# Altium schematic sheet pultboard_power.SchDoc(pultboard_power)
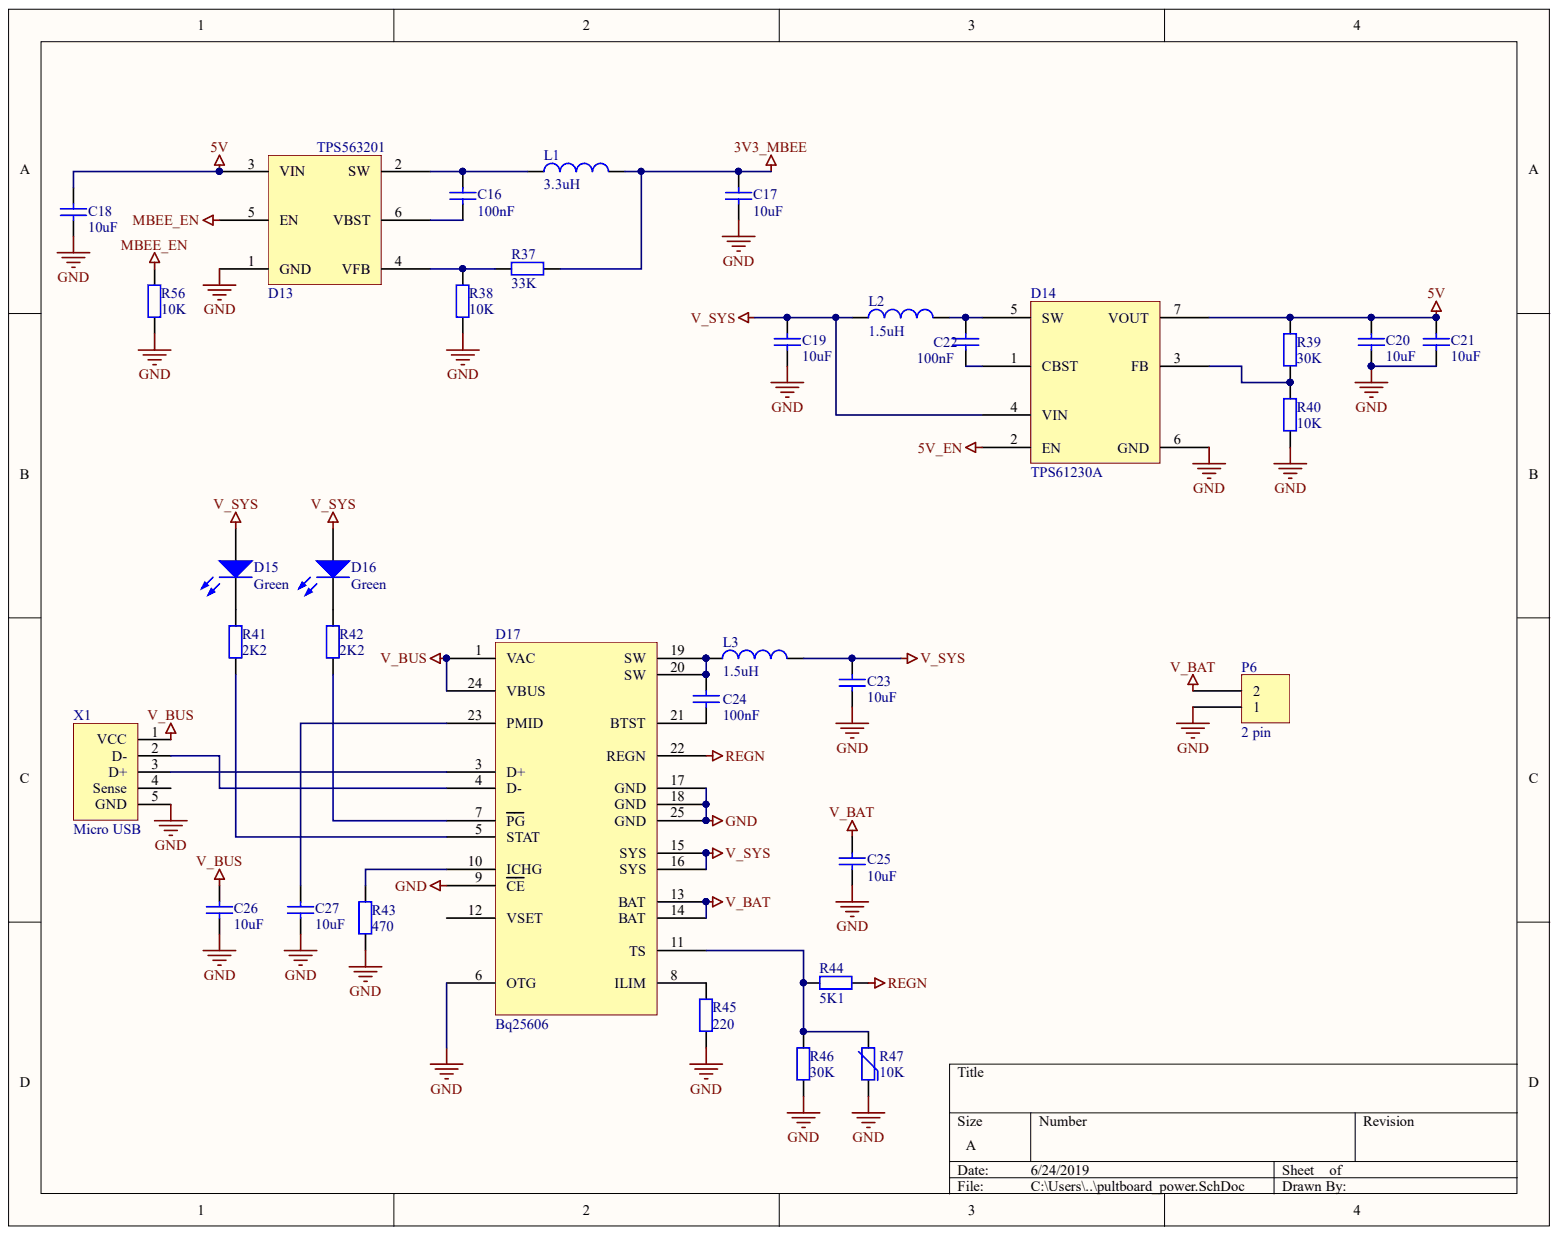
[diagram p0: pultboard_power.SchDoc]

## Parts
C16  value 100nF  [0402]  (hidden comment: Cap)
C17  value 10uF  [1206]  (hidden comment: Cap)
C18  value 10uF  [1206]  (hidden comment: Cap)
C19  value 10uF  [1206]  (hidden comment: Cap)
C20  value 10uF  [1206]  (hidden comment: Cap)
C21  value 10uF  [1206]  (hidden comment: Cap)
C22  value 100nF  [0402]  (hidden comment: Cap)
C23  value 10uF  [1206]  (hidden comment: Cap)
C24  value 100nF  [0402]  (hidden comment: Cap)
C25  value 10uF  [1206]  (hidden comment: Cap)
C26  value 10uF  [1206]  (hidden comment: Cap)
C27  value 10uF  [1206]  (hidden comment: Cap)
D13  TPS563201  [SOT23-6]
D14  TPS61230A  [VQFN7]
D15  Green  [D0603]
D16  Green  [D0603]
D17  Bq25606  [VQFN24]
L1  value 3.3uH  [SRN3015]  (hidden comment: Inductor)
L2  value 1.5uH  [SRN8040]  (hidden comment: Inductor)
L3  value 1.5uH  [SRN8040]  (hidden comment: Inductor)
P6  2 pin  [2 pin 5.08 terminal]
R37  value 33K  [0603]  (hidden comment: Res)
R38  value 10K  [0603]  (hidden comment: Res)
R39  value 30K  [0603]  (hidden comment: Res)
R40  value 10K  [0603]  (hidden comment: Res)
R41  value 2K2  [0603]  (hidden comment: Res)
R42  value 2K2  [0603]  (hidden comment: Res)
R43  value 470  [0603]  (hidden comment: Res)
R44  value 5K1  [0603]  (hidden comment: Res)
R45  value 220  [0603]  (hidden comment: Res)
R46  value 30K  [0603]  (hidden comment: Res)
R47  Resistance: 10K  [0603]  (hidden comment: Thermistor)
R56  value 10K  [0603]  (hidden comment: Res)
X1  Micro USB  Database Table Name: USB  [10104111]

## Nets
3V3_MBEE: C17.2 L1.2 R37.2
5V: C18.2 C20.2 C21.2 D13.3(VIN) D14.7(VOUT) R39.2
5V_EN: D14.2(EN)
GND: C17.1 C18.1 C19.1 C20.1 C21.1 C23.1 C25.1 C26.1 C27.1 D13.1(GND) D14.6(GND) D17.6(OTG) D17.9(CE) D17.17(GND) D17.18(GND) D17.25(GND) P6.1 R38.1 R40.1 R43.1 R45.1 R46.1 R47.1 R56.1 X1.5(GND)
MBEE_EN: D13.5(EN) R56.2
REGN: D17.22(REGN) R44.2
V_BAT: C25.2 D17.13(BAT) D17.14(BAT) P6.2
V_BUS: C26.2 D17.1(VAC) D17.24(VBUS) X1.1(VCC)
V_SYS: C19.2 C23.2 D14.4(VIN) D15.1 D16.1 D17.15(SYS) D17.16(SYS) L2.1 L3.2
NetC16_1: C16.1 D13.6(VBST)
NetC16_2: C16.2 D13.2(SW) L1.1
NetC22_1: C22.1 D14.1(CBST)
NetC22_2: C22.2 D14.5(SW) L2.2
NetC24_1: C24.1 D17.21(BTST)
NetC24_2: C24.2 D17.19(SW) D17.20(SW) L3.1
NetC27_2: C27.2 D17.23(PMID)
NetD13_4: D13.4(VFB) R37.1 R38.2
NetD14_3: D14.3(FB) R39.1 R40.2
NetD15_2: D15.2 R41.2
NetD16_2: D16.2 R42.2
NetD17_3: D17.3(D+) X1.3(D+)
NetD17_4: D17.4(D-) X1.2(D-)
NetD17_5: D17.5(STAT) R41.1
NetD17_7: D17.7(PG) R42.1
NetD17_8: D17.8(ILIM) R45.2
NetD17_10: D17.10(ICHG) R43.2
NetD17_11: D17.11(TS) R44.1 R46.2 R47.2

Not connected: D17 12(VSET); X1 4(Sense)

## Library data of the parts
C16 C22 C24 = 0402ZD104KAT2A@AVX: Capacitor; Database Table Name: Capacitor ceramic; Tolerance: 10%; Voltage rated: 10V; Component Kind: Standard; Library Name: passive.DbLib; Link: https://www.elitan.ru/price/item469194; Package: 0402; Part Number: 0402ZD104KAT2A@AVX; Supplier: elitan.ru
C17-C21 C23 C25-C27 = CL31A106KBHNNNE@SAMSUNG: Capacitor; Database Table Name: Capacitor ceramic; Tolerance: 10%; Voltage rated: 50V; Component Kind: Standard; Library Name: passive.DbLib; Link: http://www.elitan.ru/price/item4546741; Package: 1206; Part Number: CL31A106KBHNNNE@SAMSUNG; Supplier: elitan.ru
D13 = TPS563201: step down; Database Table Name: DC DC Step Down; Component Kind: Standard; Library Name: generic ic.DbLib; Package: SOT23-6; Part Number: TPS563201
D14 = TPS61230A: Step Up; Database Table Name: DC DC Step Up; Component Kind: Standard; Library Name: generic ic.DbLib; Package: VQFN7; Part Number: TPS61230A
D15 D16 = LTST-C191KGKT@LITEON: Database Table Name: Led; Component Kind: Standard; Library Name: discrete.DbLib; Part Number: LTST-C191KGKT@LITEON; Supplier: elitan.ru
D17 = Bq25606: step down & charger li-ion; Database Table Name: DC DC Step Down; Component Kind: Standard; Library Name: generic ic.DbLib; Package: VQFN24; Part Number: Bq25606
L1 = SRN3015-3R3M@BOURNS: Current: 1.4A; Tolerance: 20%; Component Kind: Standard; Database Table Name: Inductor; Description: Inductor; Library Name: passive.DbLib; Link: https://www.elitan.ru/price/item9288150; Package: 3x3mm; Part Number: SRN3015-3R3M@BOURNS; Supplier: elitan.ru
L2 L3 = SRN5040-1R5Y@BOURNS: Current: 3.6; Tolerance: 20%; Component Kind: Standard; Database Table Name: Inductor; Description: Inductor; Library Name: passive.DbLib; Package: 5x5mm; Part Number: SRN5040-1R5Y@BOURNS; Supplier: elitan.ru
P6 = Terminal Block connector 2: Default Comment: Pitch 5.08 2 pins; Number of Positions: 2; Pitch: 5.08; Component Kind: Standard; Database Table Name: Terminal Block; Library Name: connectors.DbLib; Mount type: True Hole; Part Number: Terminal Block connector 2
R37 = R_00056: Resistor; Tolerance: 5%; Component Kind: Standard; Database Table Name: Resistor 0603; Library Name: passive.DbLib; Package: 0603; Part Number: R_00056; Supplier: elitan.ru
R38 R40 R56 = R_00049: Resistor; Tolerance: 5%; Component Kind: Standard; Database Table Name: Resistor 0603; Library Name: passive.DbLib; Package: 0603; Part Number: R_00049; Supplier: elitan.ru
R39 R46 = R_00055: Resistor; Tolerance: 5%; Component Kind: Standard; Database Table Name: Resistor 0603; Library Name: passive.DbLib; Package: 0603; Part Number: R_00055; Supplier: elitan.ru
R41 R42 = R_00037: Resistor; Tolerance: 5%; Component Kind: Standard; Database Table Name: Resistor 0603; Library Name: passive.DbLib; Package: 0603; Part Number: R_00037; Supplier: elitan.ru
R43 = R_00026: Resistor; Tolerance: 5%; Component Kind: Standard; Database Table Name: Resistor 0603; Library Name: passive.DbLib; Package: 0603; Part Number: R_00026; Supplier: elitan.ru
R44 = R_00043: Resistor; Tolerance: 5%; Component Kind: Standard; Database Table Name: Resistor 0603; Library Name: passive.DbLib; Package: 0603; Part Number: R_00043; Supplier: elitan.ru
R45 = R_00021: Resistor; Tolerance: 5%; Component Kind: Standard; Database Table Name: Resistor 0603; Library Name: passive.DbLib; Package: 0603; Part Number: R_00021; Supplier: elitan.ru
R47 = NCP18XH103F03RB@MURATA: Accuracy: +-1; Max Temp: 125C; Min Temp: -40C; Tolerance: 1% (25C); Component Kind: Standard; Database Table Name: Thermistor; Description: Thermistor; Library Name: passive.DbLib; Link: http://www.elitan.ru/price/item511845; Package: 0603; Part Number: NCP18XH103F03RB@MURATA; Supplier: elitan.ru
X1 = 10104111-0001: Number of Positions: 5; Component Kind: Standard; Library Name: connectors.DbLib; Link: https://www.elitan.ru/price/item5115484; Mount type: SMD; Part Number: 10104111-0001; Supplier: elitan.ru
